# Altium schematic sheet power_input.SchDoc(power_input)
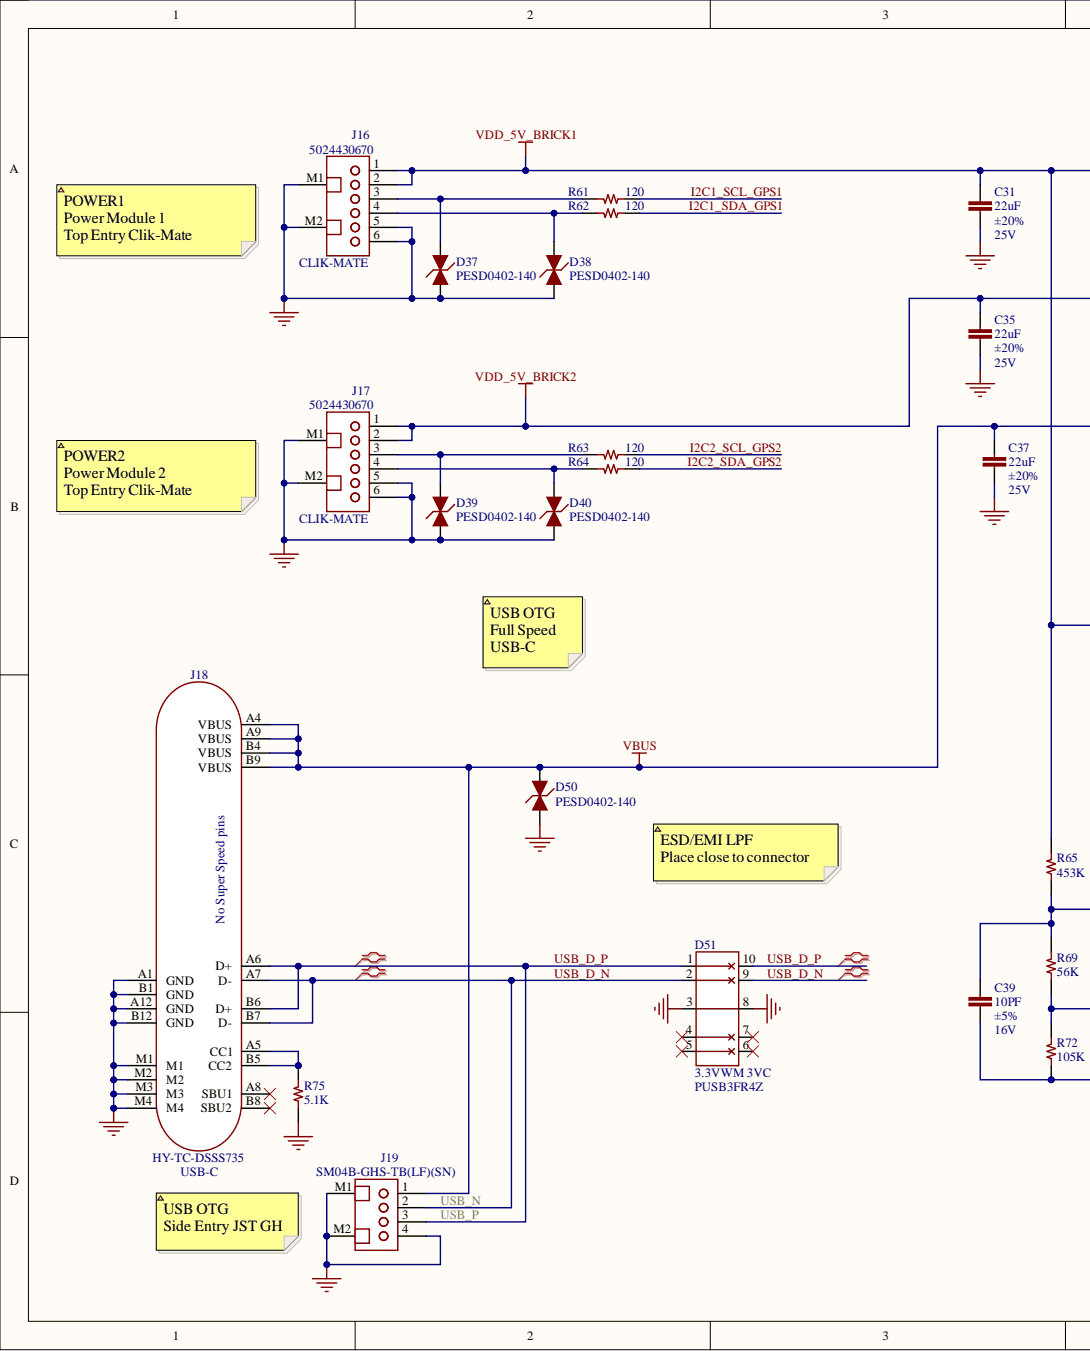
[diagram p0: power_input.SchDoc, left]
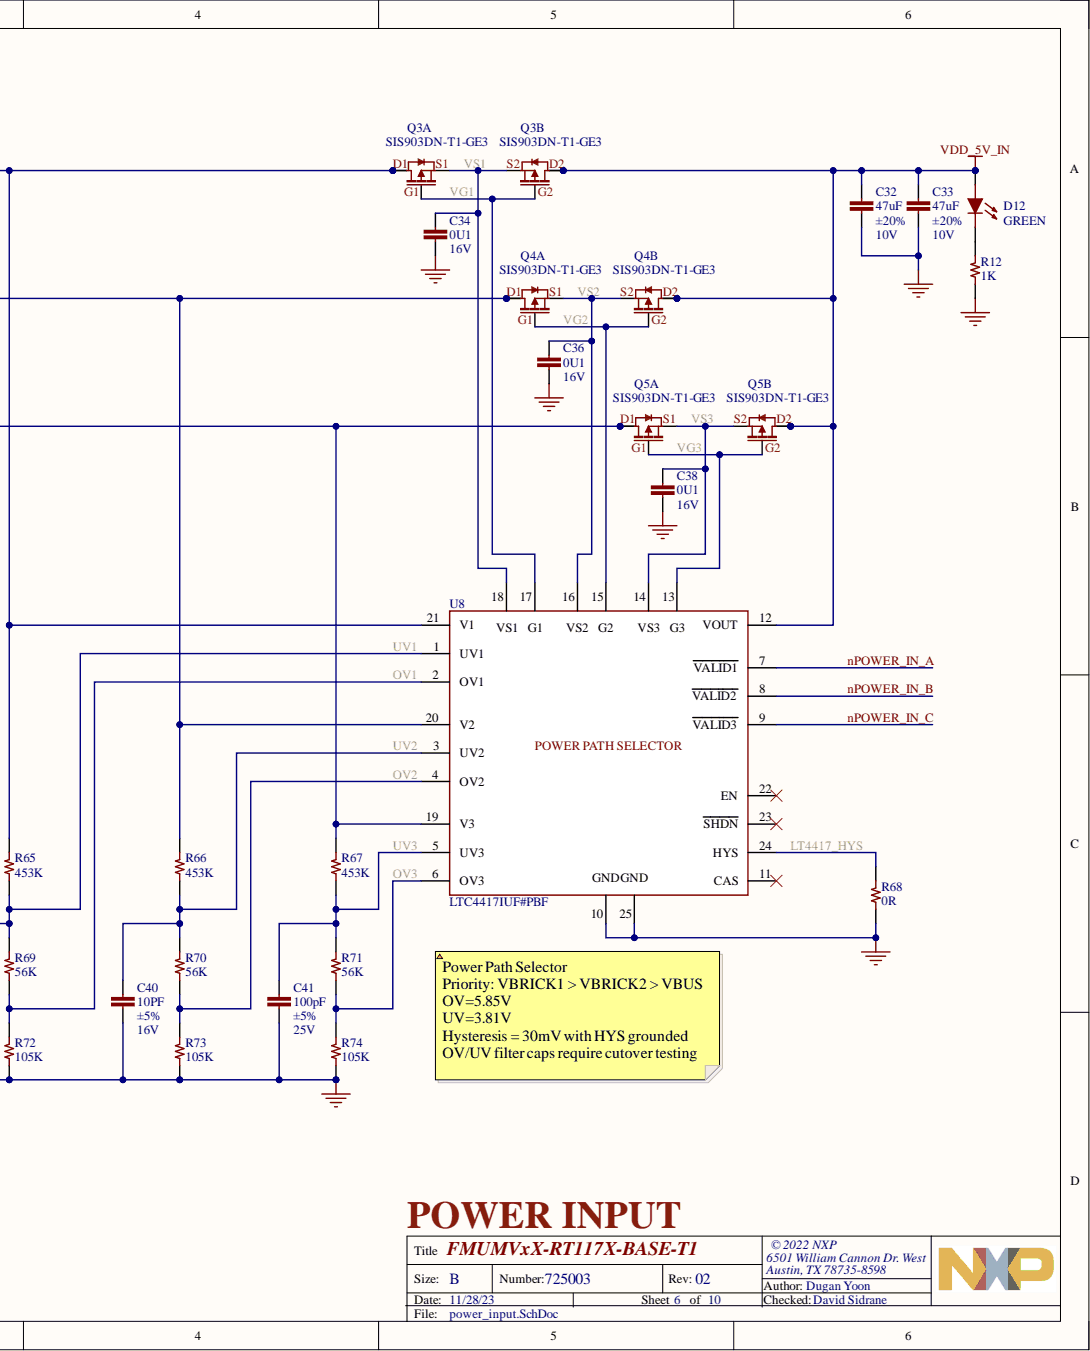
[diagram p1: power_input.SchDoc, right]

## Parts
C31  value 22uF  Tolerance: ±20%  Voltage - Rated: 25V  [CAPC200X125X70L40N]  (hidden comment: CAP CER 22UF 20% 25V X5R 0805)
C32  value 47uF  Tolerance: ±20%  Voltage - Rated: 10V  [CAPC200X125X145L45N]  (hidden comment: CAP CER 47UF 20% 10V X5R 0805)
C33  value 47uF  Tolerance: ±20%  Voltage - Rated: 10V  [CAPC200X125X145L45N]  (hidden comment: CAP CER 47UF 20% 10V X5R 0805)
C34  value 0U1  Voltage - Rated: 16V  [CAPC100X50X56L25N]  (hidden comment: CAP CER 0.1UF 10% 16V X7R 0402)
C35  value 22uF  Tolerance: ±20%  Voltage - Rated: 25V  [CAPC200X125X70L40N]  (hidden comment: CAP CER 22UF 20% 25V X5R 0805)
C36  value 0U1  Voltage - Rated: 16V  [CAPC100X50X56L25N]  (hidden comment: CAP CER 0.1UF 10% 16V X7R 0402)
C37  value 22uF  Tolerance: ±20%  Voltage - Rated: 25V  [CAPC200X125X70L40N]  (hidden comment: CAP CER 22UF 20% 25V X5R 0805)
C38  value 0U1  Voltage - Rated: 16V  [CAPC100X50X56L25N]  (hidden comment: CAP CER 0.1UF 10% 16V X7R 0402)
C39  value 10PF  Tolerance: ±5%  Voltage - Rated: 16V  [CAPC100X50X56L25N]  (hidden comment: CAP CER 10PF 5% 16V C0G/NP0 0402)
C40  value 10PF  Tolerance: ±5%  Voltage - Rated: 16V  [CAPC100X50X56L25N]  (hidden comment: CAP CER 10PF 5% 16V C0G/NP0 0402)
C41  value 100pF  Tolerance: ±5%  Voltage - Rated: 25V  [CAPC100X50X56L25N]  (hidden comment: CAP CER 100PF 5% 25V COG/NPO 0402)
D12  Color: GREEN  [LEDSC80X160X65L40N_GRN]  (hidden comment: LED GREEN CLEAR 0603 SMD)
D37  Manufacturer Part Number: PESD0402-140  [DIONC100X50X43L20N]  (hidden comment: DIODE TVS BIDIRECTIONAL 14VWM 40VC 0402)
D38  Manufacturer Part Number: PESD0402-140  [DIONC100X50X43L20N]  (hidden comment: DIODE TVS BIDIRECTIONAL 14VWM 40VC 0402)
D39  Manufacturer Part Number: PESD0402-140  [DIONC100X50X43L20N]  (hidden comment: DIODE TVS BIDIRECTIONAL 14VWM 40VC 0402)
D40  Manufacturer Part Number: PESD0402-140  [DIONC100X50X43L20N]  (hidden comment: DIODE TVS BIDIRECTIONAL 14VWM 40VC 0402)
D50  Manufacturer Part Number: PESD0402-140  [DIONC100X50X43L20N]  (hidden comment: DIODE TVS BIDIRECTIONAL 14VWM 40VC 0402)
D51  ID: 3.3VWM 3VC  Manufacturer Part Number: PUSB3FR4Z  [SON10P50_250X100X50L35X20]  (hidden comment: DIODE TVS BIDIRECTIONAL 3.3VWM 3VC DFN2510A-10)
J16  ID: CLIK-MATE  Manufacturer Part Number: 5024430670  [MOLEX_5024430670]  (hidden comment: CONN HEADER CLIK-MATE TOP 6POS 2MM SMT)
J17  ID: CLIK-MATE  Manufacturer Part Number: 5024430670  [MOLEX_5024430670]  (hidden comment: CONN HEADER CLIK-MATE TOP 6POS 2MM SMT)
J18  ID: USB-C  Manufacturer Part Number: HY-TC-DSSS735  [HOMYET_HY-TC-DSSS735]  (hidden comment: CONN RCPT 16-POS USB C HS R/A SMD)
J19  Manufacturer Part Number: SM04B-GHS-TB(LF)(SN)  [JST_SM04B-GHS-TBLFSN]  (hidden comment: CONN HEADER GH SIDE 4POS 1.25MM SMT)
Q3  Manufacturer Part Number: SIS903DN-T1-GE3  [VISHAY_POWERPAK_1212-8_DUAL]  (hidden comment: TRAN MOSFET 2P-CH 20V 6A PowerPAK)  (parts A,B)
Q4  Manufacturer Part Number: SIS903DN-T1-GE3  [VISHAY_POWERPAK_1212-8_DUAL]  (hidden comment: TRAN MOSFET 2P-CH 20V 6A PowerPAK)  (parts A,B)
Q5  Manufacturer Part Number: SIS903DN-T1-GE3  [VISHAY_POWERPAK_1212-8_DUAL]  (hidden comment: TRAN MOSFET 2P-CH 20V 6A PowerPAK)  (parts A,B)
R12  value 1K  [RESC100X50X40L25]  (hidden comment: RES SMD 1K OHM 1% 1/16W 0402)
R61  value 120  [RESC100X50X40L25]  (hidden comment: RES SMD 120 OHM 1% 1/16W 0402)
R62  value 120  [RESC100X50X40L25]  (hidden comment: RES SMD 120 OHM 1% 1/16W 0402)
R63  value 120  [RESC100X50X40L25]  (hidden comment: RES SMD 120 OHM 1% 1/16W 0402)
R64  value 120  [RESC100X50X40L25]  (hidden comment: RES SMD 120 OHM 1% 1/16W 0402)
R65  value 453K  [RESC100X50X40L25]  (hidden comment: RES SMD 453K OHM 1% 1/16W 0402)
R66  value 453K  [RESC100X50X40L25]  (hidden comment: RES SMD 453K OHM 1% 1/16W 0402)
R67  value 453K  [RESC100X50X40L25]  (hidden comment: RES SMD 453K OHM 1% 1/16W 0402)
R68  value 0R  [RESC100X50X40L25]  (hidden comment: RES SMD 0 OHM 1% 1/16W 0402)
R69  value 56K  [RESC100X50X40L25]  (hidden comment: RES SMD 56K OHM 1% 1/16W 0402)
R70  value 56K  [RESC100X50X40L25]  (hidden comment: RES SMD 56K OHM 1% 1/16W 0402)
R71  value 56K  [RESC100X50X40L25]  (hidden comment: RES SMD 56K OHM 1% 1/16W 0402)
R72  value 105K  [RESC100X50X40L25]  (hidden comment: RES SMD 105K OHM 1% 1/16W 0402)
R73  value 105K  [RESC100X50X40L25]  (hidden comment: RES SMD 105K OHM 1% 1/16W 0402)
R74  value 105K  [RESC100X50X40L25]  (hidden comment: RES SMD 105K OHM 1% 1/16W 0402)
R75  value 5.1K  [RESC100X50X40L25]  (hidden comment: RES SMD 5.1K OHM 1% 1/16W 0402)
U8  Manufacturer Part Number: LTC4417IUF#PBF  [QFN25P50_400X400X80L40X25T245N]  (hidden comment: IC OR CTRLR SRC SELECT 24QFN)

## Nets
GND: C31.2 C32.2 C33.2 C34.2 C35.2 C36.2 C37.2 C38.2 C39.2 C40.2 C41.2 D37.1 D38.1 D39.1 D40.1 D50.1 D51.3 D51.8 J16.5 J16.6 J16.M1 J16.M2 J17.5 J17.6 J17.M1 J17.M2 J18.A1(GND) J18.A12(GND) J18.B1(GND) J18.B12(GND) J18.M1 J18.M2 J18.M3 J18.M4 J19.4 J19.M1 J19.M2 R12.2 R68.2 R72.2 R73.2 R74.2 R75.2 U8.10 U8.25
VBUS: C37.1 D50.2 J18.A4(VBUS) J18.A9(VBUS) J18.B4(VBUS) J18.B9(VBUS) J19.1 Q5.7 Q5.8 R67.1 U8.19(V3)
VDD_5V_BRICK1: C31.1 J16.1 J16.2 Q3.7 Q3.8 R65.1 U8.21(V1)
VDD_5V_BRICK2: C35.1 J17.1 J17.2 Q4.7 Q4.8 R66.1 U8.20(V2)
VDD_5V_IN: C32.1 C33.1 D12.A Q3.5 Q3.6 Q4.5 Q4.6 Q5.5 Q5.6 U8.12(VOUT)
I2C1_SCL_GPS1: R61.2
I2C1_SDA_GPS1: R62.2
I2C2_SCL_GPS2: R63.2
I2C2_SDA_GPS2: R64.2
LT4417_HYS: R68.1 U8.24(HYS)
nPOWER_IN_A: U8.7(VALID1)
nPOWER_IN_B: U8.8(VALID2)
nPOWER_IN_C: U8.9(VALID3)
OV1: R69.2 R72.1 U8.2(OV1)
OV2: R70.2 R73.1 U8.4(OV2)
OV3: R71.2 R74.1 U8.6(OV3)
USB_D_N: D51.2 D51.9 J18.A7(D-) J18.B7(D-) J19.2
USB_D_P: D51.1 D51.10 J18.A6(D+) J18.B6(D+) J19.3
UV1: C39.1 R65.2 R69.1 U8.1(UV1)
UV2: C40.1 R66.2 R70.1 U8.3(UV2)
UV3: C41.1 R67.2 R71.1 U8.5(UV3)
VG1: Q3.2 Q3.4 U8.17(G1)
VG2: Q4.2 Q4.4 U8.15(G2)
VG3: Q5.2 Q5.4 U8.13(G3)
VS1: C34.1 Q3.1 Q3.3 U8.18
VS2: C36.1 Q4.1 Q4.3 U8.16
VS3: C38.1 Q5.1 Q5.3 U8.14
NetD12_C: D12.C R12.1
NetD37_2: D37.2 J16.3 R61.1
NetD38_2: D38.2 J16.4 R62.1
NetD39_2: D39.2 J17.3 R63.1
NetD40_2: D40.2 J17.4 R64.1
NetJ18_A5: J18.A5(CC1) J18.B5(CC2) R75.1

Not connected: D51 4 5 6 7; J18 A8(SBU1) B8(SBU2); U8 11(CAS) 22(EN) 23(SHDN)

## Library data of the parts
C31 C35 C37 = CAP_22UF_20%_25V_X5R_0805: Manufacturer: Murata Electronics; Manufacturer Part Number: GRT21BR61E226ME13L; Category: Capacitors; Component Kind: Standard; ComponentLink1Description: https://www.digikey.com/en/products/detail/murata-electronics/GRT21BR61E226ME13L/5416811; ComponentLink1URL: https://www.digikey.com/en/products/detail/murata-electronics/GRT21BR61E226ME13L/5416811; Description: CAP CER 22UF 20% 25V X5R 0805; Operating Temperature: -55°C ~ 125°C; Packaging: Cut Tape (CT); Part Status: Active; Pricing 1: 1=0.58 (USD); Stock 1: 0; Supplier 1: Digi-Key; Supplier Part Number 1: 490-12389-1-ND; Library Name: NscDG_Integrated_Library.SchLib
C32 C33 = CAP_47UF_20%_10V_X5R_0805: Manufacturer: Murata Electronics North America; Manufacturer Part Number: GRM21BR61A476ME15L; Category: Capacitors; Component Kind: Standard; ComponentLink1Description: https://www.digikey.com/product-detail/en/murata-electronics-north-america/GRM21BR61A476ME15L/490-9961-1-ND/5026442; ComponentLink1URL: https://www.digikey.com/product-detail/en/murata-electronics-north-america/GRM21BR61A476ME15L/490-9961-1-ND/5026442; Description: CAP CER 47UF 20% 10V X5R 0805; Operating Temperature: -55°C ~ 85°C; Packaging: Cut Tape (CT); Part Status: Active; Pricing 1: 1=0.75 (USD); Stock 1: 4315932; Supplier 1: Digi-Key; Supplier Part Number 1: 490-9961-1-ND; Library Name: NscDG_Integrated_Library.SchLib
C34 C36 C38 = CAP_0U1_16V_X7R_0402: Manufacturer: Murata Electronics; Manufacturer Part Number: GCM155R71C104KA55J; Category: Capacitors; Component Kind: Standard; ComponentLink1Description: https://www.digikey.com/product-detail/en/murata-electronics/GCM155R71C104KA55J/490-7998-1-ND/4380283; ComponentLink1URL: https://www.digikey.com/product-detail/en/murata-electronics/GCM155R71C104KA55J/490-7998-1-ND/4380283; Description: CAP CER 0.1UF 10% 16V X7R 0402; Operating Temperature: -55°C ~ 125°C; Packaging: Cut Tape (CT); Part Status: Active; Pricing 1: 1=0.1, 10=0.049, 100=0.022, 500=0.0157, 1000=0.01233, 2500=0.01121, 5000=0.01032 (USD); Stock 1: 6381653; Supplier 1: Digi-Key; Supplier Part Number 1: 490-7998-1-ND; Tolerance: ±10%; Library Name: NscDG_Integrated_Library.SchLib
C39 C40 = CAP_10PF_5%_16V_NPO_0402: Manufacturer: Wurth Electronics Inc.; Manufacturer Part Number: 885012005025; Temperature Coefficient: C0G, NP0; Category: Capacitors; Component Kind: Standard; ComponentLink1Description: https://www.digikey.com/product-detail/en/wurth-electronics-inc/885012005025/732-7441-1-ND/5454068; ComponentLink1URL: https://www.digikey.com/product-detail/en/wurth-electronics-inc/885012005025/732-7441-1-ND/5454068; Description: CAP CER 10PF 5% 16V C0G/NP0 0402; Operating Temperature: -55°C ~ 125°C; Packaging: Cut Tape (CT); Part Status: Active; Pricing 1: 1=0.1, 10=0.032, 100=0.0143, 500=0.0102, 1000=0.00802, 2500=0.00729, 5000=0.0067 (USD); Stock 1: 79611; Supplier 1: Digi-Key; Supplier Part Number 1: 732-7441-1-ND; Library Name: NscDG_Integrated_Library.SchLib
C41 = CAP_100PF_5%_25V_NPO_0402: Manufacturer: KEMET; Manufacturer Part Number: C0402C101J3GACTU; Category: Capacitors; Component Kind: Standard; ComponentLink1Description: https://www.digikey.com/product-detail/en/kemet/C0402C101J3GACTU/399-1022-1-ND/411297; ComponentLink1URL: https://www.digikey.com/product-detail/en/kemet/C0402C101J3GACTU/399-1022-1-ND/411297; Description: CAP CER 100PF 5% 25V COG/NPO 0402; Operating Temperature: -55°C ~ 125°C; Packaging: Cut Tape (CT); Part Status: Active; Pricing 1: 1=0.1, 10=0.02, 100=0.0091, 500=0.0065, 1000=0.00511, 2500=0.00465, 5000=0.00428 (USD); Stock 1: 300; Supplier 1: Digi-Key; Supplier Part Number 1: 399-1022-1-ND; Library Name: NscDG_Integrated_Library.SchLib
D12 = DIODE_LED_GREEN_0603: Manufacturer: Lite-On Inc.; Manufacturer Part Number: LTST-C191KGKT; Category: Optoelectronics; Component Kind: Standard; ComponentLink1Description: http://optoelectronics.liteon.com/upload/download/DS22-2000-228/LTST-C191KGKT.PDF; ComponentLink1URL: http://optoelectronics.liteon.com/upload/download/DS22-2000-228/LTST-C191KGKT.PDF; ComponentLink2Description: https://www.digikey.com/product-detail/en/lite-on-inc/LTST-C191KGKT/160-1446-1-ND/386834; ComponentLink2URL: https://www.digikey.com/product-detail/en/lite-on-inc/LTST-C191KGKT/160-1446-1-ND/386834; Description: LED GREEN CLEAR 0603 SMD; Packaging: Cut Tape (CT); Part Status: Active; Pricing 1: 1=0.34, 10=0.253, 25=0.1872, 100=0.1353 (USD); Stock 1: 2166001; Supplier 1: Digi-Key; Supplier Part Number 1: 160-1446-1-ND; Library Name: NscDG_Integrated_Library.SchLib
D37-D40 D50 = DIODE_TVS_0402: Manufacturer: Littelfuse Inc.; Category: Circuit Protection; Component Kind: Standard; ComponentLink1Description: https://www.digikey.com/products/en/circuit-protection/tvs-diodes/144?k=PESD0402-140&k=&pkeyword=PESD0402-140&pv7=2&sf=0&quantity=&ColumnSort=0&page=1&pageSize=25; ComponentLink1URL: https://www.digikey.com/products/en/circuit-protection/tvs-diodes/144?k=PESD0402-140&k=&pkeyword=PESD0402-140&pv7=2&sf=0&quantity=&ColumnSort=0&page=1&pageSize=25; Description: DIODE TVS BIDIRECTIONAL 14VWM 40VC 0402; Operating Temperature: -55°C ~ 125°C (TJ); Packaging: Cut Tape (CT); Part Status: Active; Pricing 1: 10000=0.13154, 30000=0.12096 (USD); Stock 1: 281442; Supplier 1: Digi-Key; Supplier Part Number 1: PESD0402-140CT-ND; Library Name: NscDG_Integrated_Library.SchLib
D51 = DIODE_TVS_4X_3.3VWM_3VC_DFN2510A-10: Manufacturer: Nexperia USA Inc.; Category: Circuit Protection; Component Kind: Standard; ComponentLink1Description: https://www.digikey.com/en/products/detail/nexperia-usa-inc/PUSB3FR4Z/5216193?s=N4IgTCBcDaIAoFUDKAhAzAMQEoBYQF0BfIA; ComponentLink1URL: https://www.digikey.com/en/products/detail/nexperia-usa-inc/PUSB3FR4Z/5216193?s=N4IgTCBcDaIAoFUDKAhAzAMQEoBYQF0BfIA; Description: DIODE TVS BIDIRECTIONAL 3.3VWM 3VC DFN2510A-10; Operating Temperature: -40°C ~ 125°C (TJ); Packaging: Cut Tape (CT); Part Status: Active; Pricing 1: 1=0.45 (USD); Stock 1: 260800; Supplier 1: Digi-Key; Supplier Part Number 1: 1727-2026-1-ND; Library Name: NscDG_Integrated_Library.SchLib
J16 J17 = CONN_HDR_1X6_RCPT_2MM_VERT_SMT: Manufacturer: Molex, LLC; System: Connector; Voltage - Rated: 50V; Category: Connectors, Interconnects; Component Kind: Standard; ComponentLink1Description: https://www.digikey.com/en/products/detail/molex/5024430670/2380429?s=N4IgTCBcDaIKwAYwBZkGYEDYDsCQF0BfIA; ComponentLink1URL: https://www.digikey.com/en/products/detail/molex/5024430670/2380429?s=N4IgTCBcDaIKwAYwBZkGYEDYDsCQF0BfIA; Description: CONN HEADER CLIK-MATE TOP 6POS 2MM SMT; Operating Temperature: -40°C ~ 105°C; Packaging: Cut Tape (CT); Part Status: Active; Pricing 1: 1=0.78 (USD); Stock 1: 29603; Supplier 1: Digi-Key; Supplier Part Number 1: WM2279CT-ND; Library Name: NscDG_Integrated_Library.SchLib
J18 = CONN_RCPT_16POS_USB-C_HS_RA_SMD: Manufacturer: SHEN ZHEN HOMYET PARTS ELECTRONICS CO.,LTD; System: Connector; Category: Connectors, Interconnects; Component Kind: Standard; ComponentLink1Description: http://www.homyet.com/en/products/1959.html; ComponentLink1URL: http://www.homyet.com/en/products/1959.html; Description: CONN RCPT 16-POS USB C HS R/A SMD; Packaging: Cut Tape (CT); Part Status: Active; Pricing 1: 1= (USD); Supplier Part Number 1: WM17992CT-ND; Library Name: NscDG_Integrated_Library.SchLib
J19 = CONN_JST_GH_4PIN_RA_SMT: Manufacturer: JST Sales America Inc.; System: Connector; Voltage Rating: 50V; Category: Connectors, Interconnects; Component Kind: Standard; ComponentLink1Description: https://www.digikey.com/products/en/connectors-interconnects/rectangular-connectors-headers-male-pins/314?k=SM04B-GHS-TB%28LF%29%28SN%29-&k=&pkeyword=SM04B-GHS-TB%28LF%29%28SN%29-&pv7=2&sf=0&quantity=&ColumnSort=0&page=1&pageSize=25; ComponentLink1URL: https://www.digikey.com/products/en/connectors-interconnects/rectangular-connectors-headers-male-pins/314?k=SM04B-GHS-TB%28LF%29%28SN%29-&k=&pkeyword=SM04B-GHS-TB%28LF%29%28SN%29-&pv7=2&sf=0&quantity=&ColumnSort=0&page=1&pageSize=25; Description: CONN HEADER GH SIDE 4POS 1.25MM SMT; Operating Temperature: -25°C ~ 85°C; Packaging: Cut Tape (CT); Part Status: Active; Pricing 1: 1=0.45, 10=0.423, 100=0.3244, 500=0.28212, 1000=0.23274 (USD); Stock 1: 109892; Supplier 1: Digi-Key; Supplier Part Number 1: 455-1566-1-ND; Library Name: NscDG_Integrated_Library.SchLib
Q3-Q5 = TRAN_MOSFET_DUAL_PCHANNEL_PowerPAK: Manufacturer: Vishay Siliconix; Category: Discrete Semiconductor Products; Component Kind: Standard; ComponentLink1Description: https://www.digikey.com/products/en/discrete-semiconductor-products/transistors-fets-mosfets-arrays/289?k=sis903dn&k=&pkeyword=sis903dn&sv=0&pv7=2&sf=0&quantity=&ColumnSort=0&page=1&pageSize=25; ComponentLink1URL: https://www.digikey.com/products/en/discrete-semiconductor-products/transistors-fets-mosfets-arrays/289?k=sis903dn&k=&pkeyword=sis903dn&sv=0&pv7=2&sf=0&quantity=&ColumnSort=0&page=1&pageSize=25; Current - Continuous Drain (Id) @ 25°C: 6A; Description: TRAN MOSFET 2P-CH 20V 6A PowerPAK; Drain to Source Voltage (Vdss): 20V; Operating Temperature: -55°C ~ 150°C (TJ); Packaging: Cut Tape (CT); Part Status: Active; Pricing 1: 1=1.01 (USD); RoHS: RoHS Compliant; Stock 1: 0; Supplier 1: Digi-Key; Supplier Part Number 1: SIS903DN-T1-GE3CT-ND; Library Name: NscDG_Integrated_Library.SchLib
R12 = RES_1K_1%_1/16W_0402: Manufacturer: Yageo; Manufacturer Part Number: RC0402FR-071KL; Category: Resistors; Component Kind: Standard; ComponentLink1Description: https://www.digikey.com/product-detail/en/yageo/RC0402FR-071KL/311-1.00KLRCT-ND/729460; ComponentLink1URL: https://www.digikey.com/product-detail/en/yageo/RC0402FR-071KL/311-1.00KLRCT-ND/729460; Description: RES SMD 1K OHM 1% 1/16W 0402; Operating Temperature: -55°C ~ 155°C; Packaging: Cut Tape (CT); Part Status: Active; Power: 1/16W; Pricing 1: 1=0.1, 10=0.014, 100=0.0056, 1000=0.00253, 2500=0.0022, 5000=0.00181 (USD); Stock 1: 18685853; Supplier 1: Digi-Key; Supplier Part Number 1: 311-1.00KLRCT-ND; Tolerance: ±1%; Library Name: NscDG_Integrated_Library.SchLib
R61-R64 = RES_120_1%_1/16W_0402: Manufacturer: Yageo; Manufacturer Part Number: RC0402FR-07120RL; Category: Resistors; Component Kind: Standard; ComponentLink1Description: https://www.digikey.com/products/en/resistors/chip-resistor-surface-mount/52?k=RC0402FR-07120RL&k=&pkeyword=RC0402FR-07120RL&pv7=2&sf=0&quantity=&ColumnSort=0&page=1&pageSize=25; ComponentLink1URL: https://www.digikey.com/products/en/resistors/chip-resistor-surface-mount/52?k=RC0402FR-07120RL&k=&pkeyword=RC0402FR-07120RL&pv7=2&sf=0&quantity=&ColumnSort=0&page=1&pageSize=25; Description: RES SMD 120 OHM 1% 1/16W 0402; Operating Temperature: -55°C ~ 155°C; Packaging: Cut Tape (CT); Part Status: Active; Power: 1/16W; Pricing 1: 1=0.1, 10=0.014, 100=0.0056, 1000=0.00253, 2500=0.0022, 5000=0.00181 (USD); Stock 1: 607269; Supplier 1: Digi-Key; Supplier Part Number 1: 311-120LRCT-ND; Tolerance: ±1%; Library Name: NscDG_Integrated_Library.SchLib
R65-R67 = RES_453K_1%_1/16W_0402: Manufacturer: Yageo; Manufacturer Part Number: RC0402FR-07453KL; Category: Resistors; Component Kind: Standard; ComponentLink1Description: https://www.digikey.com/products/en/resistors/chip-resistor-surface-mount/52?k=&pkeyword=&pv2085=u453+kOhms&pv2=1&sf=0&FV=fffc000d%2C1c0002%2C1f140000%2Cffe00034%2Cc0001%2C2b80016&quantity=&ColumnSort=0&page=1&pageSize=25; ComponentLink1URL: https://www.digikey.com/products/en/resistors/chip-resistor-surface-mount/52?k=&pkeyword=&pv2085=u453+kOhms&pv2=1&sf=0&FV=fffc000d%2C1c0002%2C1f140000%2Cffe00034%2Cc0001%2C2b80016&quantity=&ColumnSort=0&page=1&pageSize=25; Description: RES SMD 453K OHM 1% 1/16W 0402; Operating Temperature: -55°C ~ 155°C; Packaging: Cut Tape (CT); Part Status: Active; Power: 1/16W; Pricing 1: 1=0.1, 10=0.014, 100=0.0056, 1000=0.00253, 2500=0.0022, 5000=0.00181 (USD); Stock 1: 1103957; Supplier 1: Digi-Key; Supplier Part Number 1: YAG3164CT-ND; Tolerance: ±1%; Library Name: NscDG_Integrated_Library.SchLib
R68 = RES_0R_1%_1/16W_0402: Manufacturer: Yageo; Manufacturer Part Number: RC0402JR-070RL; Category: Resistors; Component Kind: Standard; ComponentLink1Description: https://www.digikey.com/product-detail/en/yageo/RC0402JR-070RL/311-0.0JRCT-ND/729353; ComponentLink1URL: https://www.digikey.com/product-detail/en/yageo/RC0402JR-070RL/311-0.0JRCT-ND/729353; Description: RES SMD 0 OHM 1% 1/16W 0402; Operating Temperature: -55°C ~ 155°C; Packaging: Cut Tape (CT); Part Status: Active; Power: 1/16W; Pricing 1: 1=0.1, 10=0.014, 100=0.0056, 1000=0.00253, 2500=0.0022, 5000=0.00181 (USD); Stock 1: 34962204; Supplier 1: Digi-Key; Supplier Part Number 1: 311-0.0JRCT-ND; Tolerance: ±1%; Library Name: NscDG_Integrated_Library.SchLib
R69-R71 = RES_56K_1%_1/16W_0402: Manufacturer: Yageo; Manufacturer Part Number: AC0402FR-0756KL; Category: Resistors; Component Kind: Standard; ComponentLink1Description: https://www.digikey.com/product-detail/en/yageo/AC0402FR-0756KL/YAG3501CT-ND/6006350; ComponentLink1URL: https://www.digikey.com/product-detail/en/yageo/AC0402FR-0756KL/YAG3501CT-ND/6006350; Description: RES SMD 56K OHM 1% 1/16W 0402; Operating Temperature: -55°C ~ 155°C; Packaging: Cut Tape (CT); Part Status: Active; Power: 1/16W; Pricing 1: 1=0.1, 10=0.014, 100=0.0056, 1000=0.00253, 2500=0.0022, 5000=0.00181 (USD); Stock 1: 261; Supplier 1: Digi-Key; Supplier Part Number 1: YAG3501CT-ND; Tolerance: ±1%; Library Name: NscDG_Integrated_Library.SchLib
R72-R74 = RES_105K_1%_1/16W_0402: Manufacturer: Yageo; Manufacturer Part Number: RC0402FR-07105KL; Category: Resistors; Component Kind: Standard; ComponentLink1Description: https://www.digikey.com/product-detail/en/yageo/RC0402FR-07105KL/YAG2946CT-ND/5281811; ComponentLink1URL: https://www.digikey.com/product-detail/en/yageo/RC0402FR-07105KL/YAG2946CT-ND/5281811; Description: RES SMD 105K OHM 1% 1/16W 0402; Operating Temperature: -55°C ~ 155°C; Packaging: Cut Tape (CT); Part Status: Active; Power: 1/16W; Pricing 1: 1=0.1, 10=0.014, 100=0.0056, 1000=0.00253, 2500=0.0022, 5000=0.00181 (USD); Stock 1: 1079008; Supplier 1: Digi-Key; Supplier Part Number 1: YAG2946CT-ND; Tolerance: ±1%; Library Name: NscDG_Integrated_Library.SchLib
R75 = RES_5.1K_1%_1/16W_0402: Manufacturer: Yageo; Manufacturer Part Number: RC0402FR-075K1L; Category: Resistors; Component Kind: Standard; ComponentLink1Description: https://www.digikey.com/product-detail/en/yageo/RC0402FR-075K1L/311-5.10KLRCT-ND/729571; ComponentLink1URL: https://www.digikey.com/product-detail/en/yageo/RC0402FR-075K1L/311-5.10KLRCT-ND/729571; Description: RES SMD 5.1K OHM 1% 1/16W 0402; Operating Temperature: -55°C ~ 155°C; Packaging: Cut Tape (CT); Part Status: Active; Power: 1/16W; Pricing 1: 1=0.1, 10=0.014, 100=0.0056, 1000=0.00253, 2500=0.0022, 5000=0.00181 (USD); Stock 1: 4559073; Supplier 1: Digi-Key; Supplier Part Number 1: 311-5.10KLRCT-ND; Tolerance: ±1%; Library Name: NscDG_Integrated_Library.SchLib
U8 = IC_LTC4417: Manufacturer: Linear Technology/Analog Devices; Current - Supply: 28µA; FET Type: P-Channel; Ratio - Input: 3:1; Voltage - Supply: 2.5 V ~ 36 V; Applications: Battery Backup, Handheld/Mobile Devices; Category: Integrated Circuits (ICs); Component Kind: Standard; ComponentLink1Description: https://www.digikey.com/product-detail/en/linear-technology-analog-devices/LTC4417IUF-PBF/LTC4417IUF-PBF-ND/3838535; ComponentLink1URL: https://www.digikey.com/product-detail/en/linear-technology-analog-devices/LTC4417IUF-PBF/LTC4417IUF-PBF-ND/3838535; Current - Output (Max): -; Description: IC OR CTRLR SRC SELECT 24QFN; Mounting Type: Surface Mount; Operating Temperature: -40°C ~ 85°C; Package / Case: 24-WFQFN Exposed Pad; Packaging: Tube; Part Status: Active; Pricing 1: 1=8.73 (USD); RoHS: RoHS Compliant; Stock 1: 7448; Supplier 1: Digi-Key; Supplier Device Package: 24-QFN (4x4); Supplier Part Number 1: LTC4417IUF#PBF-ND; Type: Source Selector Switch; Library Name: NscDG_Integrated_Library.SchLib
